# Altium schematic sheet SBPeripherals.SchDoc(SBPeripherals)
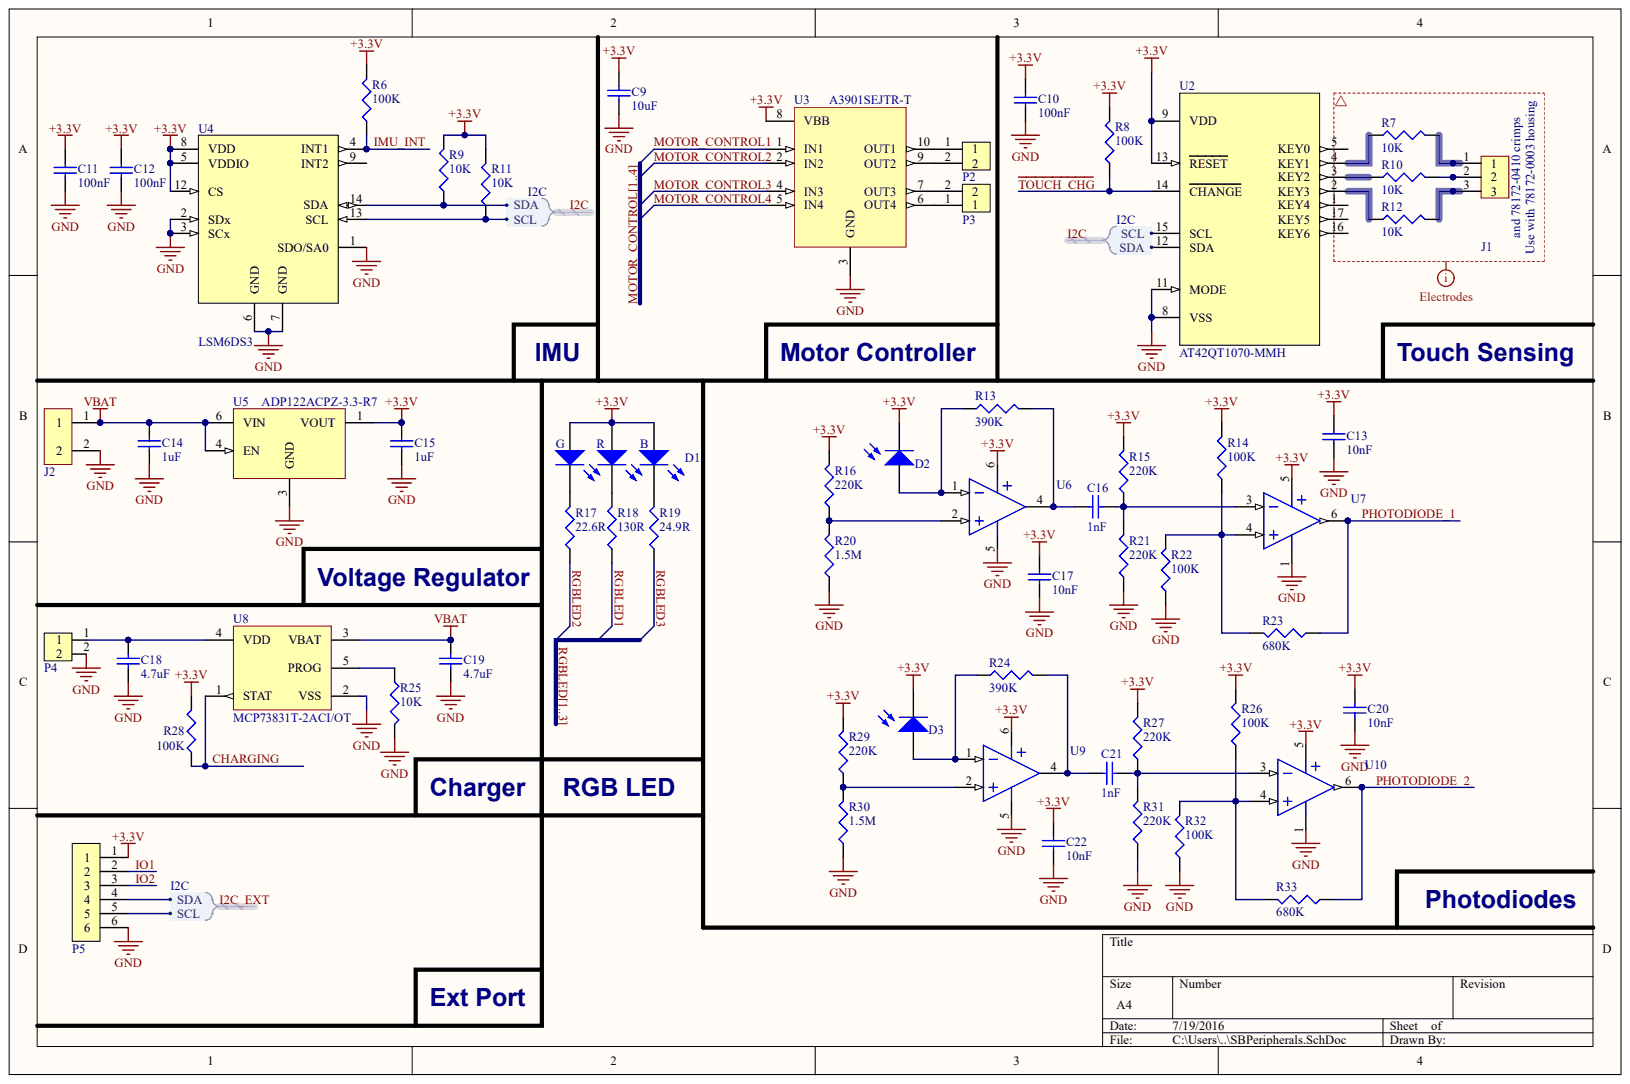
[diagram p0: SBPeripherals.SchDoc]

## Parts
C9  10uF  [0603]
C10  100nF  [0402]
C11  100nF  [0402]
C12  100nF  [0402]
C13  10nF  [0402]
C14  1uF  [0603]
C15  1uF  [0603]
C16  1nF  [0402]
C17  10nF  [0402]
C18  4.7uF  [0603]
C19  4.7uF  [0603]
C20  10nF  [0402]
C21  1nF  [0402]
C22  10nF  [0402]
D1  SMLVN6RGB1W1  [3.5x2.8 mm 6-SMD]  (value not drawn)
D2  PD15-22C/TR8  [PD15-22C/TR8]  (value not drawn)
D3  PD15-22C/TR8  [PD15-22C/TR8]  (value not drawn)
J1  78171-0003  [78171-0003]  (value not drawn)
J2  78171-0002  [78171-0002]  (value not drawn)
P2  HEADER 2X1 0.05" UNPOLARIZED  [HEADER 2X1 0.05" - UNPOLARIZED]  (value not drawn)
P3  HEADER 2X1 0.05" UNPOLARIZED  [HEADER 2X1 0.05" - UNPOLARIZED]  (value not drawn)
P4  CHARGING PAD  [Charging Pad]  (value not drawn)
P5  EXTENSION_PORT  [EXTENSION_PORT]  (value not drawn)
R6  100K  [0402]
R7  10K  [0402]
R8  100K  [0402]
R9  10K  [0402]
R10  10K  [0402]
R11  10K  [0402]
R12  10K  [0402]
R13  390K  [0402]
R14  100K  [0402]
R15  220K  [0402]
R16  220K  [0402]
R17  22.6R  [0402]
R18  130R  [0402]
R19  24.9R  [0402]
R20  1.5M  [0402]
R21  220K  [0402]
R22  100K  [0402]
R23  680K  [0402]
R24  390K  [0402]
R25  10K  [0402]
R26  100K  [0402]
R27  220K  [0402]
R28  100K  [0402]
R29  220K  [0402]
R30  1.5M  [0402]
R31  220K  [0402]
R32  100K  [0402]
R33  680K  [0402]
U2  AT42QT1070-MMH  [QFN45P300X300X85_HS-21L]
U3  A3901SEJTR-T  [DFN50P300X300X75_HS-11N]
U4  LSM6DS3  [LGA-14 2.5x3x0.86 mm 14L]
U5  ADP122ACPZ-3.3-R7  [LFCSP-6 2.0X2.0X0.55]
U6  TSV991AIQ1T  [DFN6-1.3x1.6x0.55]  (value not drawn)
U7  NCS2200AMUT1G  [UDFN6-1.2x1.0]  (value not drawn)
U8  MCP73831T-2ACI/OT  [SOT-23-OT5_L]
U9  TSV991AIQ1T  [DFN6-1.3x1.6x0.55]  (value not drawn)
U10  NCS2200AMUT1G  [UDFN6-1.2x1.0]  (value not drawn)

## Nets
+3.3V: C9.2 C10.2 C11.2 C12.2 C13.2 C15.2 C17.2 C20.2 C22.2 D1.1 D1.2 D1.3 D2.2 D3.2 P5.1 R6.2 R8.2 R9.2 R11.2 R14.2 R15.2 R16.2 R26.2 R27.2 R28.2 R29.2 U2.9(VDD) U2.13(RESET) U3.8(VBB) U4.5(VDDIO) U4.8(VDD) U4.12(CS) U5.1(VOUT) U6.6 U7.5 U9.6 U10.5
GND: C9.1 C10.1 C11.1 C12.1 C13.1 C14.1 C15.1 C17.1 C18.1 C19.1 C20.1 C22.1 J2.2 P4.2 P5.6 R20.1 R21.1 R22.1 R25.2 R30.1 R31.1 R32.1 U2.8(VSS) U2.11(MODE) U2.21 U3.3(GND) U3.11 U4.1(SDO/SA0) U4.2(SDx) U4.3(SCx) U4.6(GND) U4.7(GND) U5.2 U5.3(GND) U5.5 U5.7 U6.5 U7.1 U8.2(VSS) U9.5 U10.1
VBAT: C14.2 C19.2 J2.1 U5.4(EN) U5.6(VIN) U8.3(VBAT)
CHARGING: R28.1 U8.1(STAT)
I2C.SCL: R11.1 U2.15(SCL) U4.13(SCL)
I2C.SDA: R9.1 U2.12(SDA) U4.14(SDA)
I2C_EXT.SCL: P5.5
I2C_EXT.SDA: P5.4
IMU_INT: R6.1 U4.4(INT1)
IO1: P5.2
IO2: P5.3
MOTOR_CONTROL1: U3.1(IN1)  [also labelled MOTOR_CONTROL]
MOTOR_CONTROL2: U3.2(IN2)  [also labelled MOTOR_CONTROL]
MOTOR_CONTROL3: U3.4(IN3)  [also labelled MOTOR_CONTROL]
MOTOR_CONTROL4: U3.5(IN4)  [also labelled MOTOR_CONTROL]
PHOTODIODE_1: R23.1 U7.6
PHOTODIODE_2: R33.1 U10.6
RGBLED1: R18.1  [also labelled RGBLED]
RGBLED2: R17.1  [also labelled RGBLED]
RGBLED3: R19.1  [also labelled RGBLED]
~{TOUCH_CHG}: R8.1 U2.14(CHANGE)
NetC16_1: C16.1 R13.2 U6.4
NetC16_2: C16.2 R15.1 R21.2 U7.3
NetC18_2: C18.2 P4.1 U8.4(VDD)
NetC21_1: C21.1 R24.2 U9.4
NetC21_2: C21.2 R27.1 R31.2 U10.3
NetD1_4: D1.4 R17.2
NetD1_5: D1.5 R18.2
NetD1_6: D1.6 R19.2
NetD2_1: D2.1 R13.1 U6.1
NetD3_1: D3.1 R24.1 U9.1
NetJ1_1: J1.1 R7.2
NetJ1_2: J1.2 R10.2
NetJ1_3: J1.3 R12.2
NetP2_1: P2.1 U3.10(OUT1)
NetP2_2: P2.2 U3.9(OUT2)
NetP3_1: P3.1 U3.6(OUT4)
NetP3_2: P3.2 U3.7(OUT3)
NetR7_1: R7.1 U2.4(KEY1)
NetR10_1: R10.1 U2.3(KEY2)
NetR12_1: R12.1 U2.2(KEY3)
NetR14_1: R14.1 R22.2 R23.2 U7.4
NetR16_1: R16.1 R20.2 U6.2
NetR25_1: R25.1 U8.5(PROG)
NetR26_1: R26.1 R32.2 R33.2 U10.4
NetR29_1: R29.1 R30.2 U9.2
N00068:

Not connected: U2 1(KEY4) 5(KEY0) 16(KEY6) 17(KEY5); U4 9(INT2)

## Library data of the parts
C9 = 10uF: 10uF 0603 X5R 6.3V ±20%; Component Kind: Standard; Library Name: SBComponentsSchematics.SchLib; Supplier 1: Farnell; Supplier 2: Digi-Key; Supplier Part Number 1: 2456110; Supplier Part Number 2: 490-10475-1-ND; Value: 10uF
C10-C12 = 100nF: 100nF 0402 X7R 16V ±10%; Component Kind: Standard; Library Name: SBComponentsSchematics.SchLib; Supplier 1: Farnell; Supplier 2: Digi-Key; Supplier Part Number 1: 1288252; Supplier Part Number 2: 399-3521-1-ND; Value: 100nF
C13 C17 C20 C22 = 10nF: 10nF 0402 X7R 25V ±5%; Component Kind: Standard; Library Name: SBComponentsSchematics.SchLib; Supplier 1: Farnell; Supplier 2: Digi-Key; Supplier Part Number 1: 1692285; Supplier Part Number 2: 399-1279-1-ND; Value: 10nF
C14 C15 = 1uF: 1uF 0603 X5R 10V ±10%; Component Kind: Standard; Library Name: SBComponentsSchematics.SchLib; Supplier 1: Farnell; Supplier 2: Digi-Key; Supplier Part Number 1: 1759399; Supplier Part Number 2: 478-1251-1-ND; Value: 1uF
C16 C21 = 1nF: 1nF 0402 X7R 50V ±10%; Component Kind: Standard; Library Name: SBComponentsSchematics.SchLib; Supplier 1: Farnell; Supplier 2: Digi-Key; Supplier Part Number 1: 1414573; Supplier Part Number 2: 399-1032-1-ND; Value: 1nF
C18 C19 = 4.7uF: 4.7uF 0603 X5R 10V ±10%; Component Kind: Standard; Library Name: SBComponentsSchematics.SchLib; Supplier 1: Farnell; Supplier 2: Digi-Key; Supplier Part Number 1: 2362098; Supplier Part Number 2: 445-5170-1-ND; Value: 4.7uF
D1 = SMLVN6RGB1W1: ROHM SMLVN6RGB1W1 LED Tri-Color Blue/Green/Red Chip LED 6-Pin 1411 T/RLED Tri-Color Blue/Green/Red Chip LED 6-Pin 1411; Component Kind: Standard; Library Name: SBComponentsSchematics.SchLib; Supplier 1: Mouser; Supplier 2: Digi-Key; Supplier Part Number 1: 755-SMLVN6RGB1W1; Supplier Part Number 2: 846-1187-1-ND
D2 D3 = PD15-22C/TR8: Everlight PD15-22C/TR8 Silicon PIN Photodiode; Component Kind: Standard; Library Name: SBComponentsSchematics.SchLib; Supplier 1: Digi-Key; Supplier Part Number 1: 1080-1367-1-ND
J1 = 78171-0003: Molex Pico-EZmate™ Wire-to-Board Header, 3 contacts; Component Kind: Standard; Library Name: SBComponentsSchematics.SchLib; Supplier 1: Farnell; Supplier 2: Digi-Key; Supplier Part Number 1: 2313562; Supplier Part Number 2: WM5409CT-ND
J2 = 78171-0002: Molex Pico-EZmate™ Wire-to-Board Header, 2 contacts; Component Kind: Standard; Library Name: SBComponentsSchematics.SchLib; Supplier 1: Farnell; Supplier 2: Digi-Key; Supplier Part Number 1: 2313710; Supplier Part Number 2: WM4459CT-ND
R6 R8 R14 R22 R26 R28 R32 = 100K: 100K 0402 1/16W ±1%; Component Kind: Standard; Library Name: SBComponentsSchematics.SchLib; Supplier 1: Farnell; Supplier 2: Digi-Key; Supplier Part Number 1: 2072519; Supplier Part Number 2: 541-100KLCT-ND; Value: 100K
R7 R9-R12 R25 = 10K: 10K 0402 1/16W ±1%; Component Kind: Standard; Library Name: SBComponentsSchematics.SchLib; Supplier 1: Farnell; Supplier 2: Digi-Key; Supplier Part Number 1: 2072517; Supplier Part Number 2: 541-10.0KLCT-ND; Value: 10K
R13 R24 = 390K: 390K 0402 1/16W ±1%; Component Kind: Standard; Library Name: SBComponentsSchematics.SchLib; Supplier 1: Farnell; Supplier 2: Digi-Key; Supplier 3: Mouser; Supplier Part Number 1: 2302905; Supplier Part Number 2: P390KLCT-ND; Supplier Part Number 3: 667-ERJ-2RKF3903X; Value: 390K
R15 R16 R21 R27 R29 R31 = 220K: 220K 0402 1/16W ±1%; Component Kind: Standard; Library Name: SBComponentsSchematics.SchLib; Supplier 1: Farnell; Supplier 2: Digi-Key; Supplier 3: Mouser; Supplier Part Number 1: 9239510; Supplier Part Number 2: 311-220KLRCT-ND; Supplier Part Number 3: 603-RC0402FR-07220KL; Value: 220K
R17 = 22.6R: 22.6R 0402 1/16W ±0.05R; Component Kind: Standard; Library Name: SBComponentsSchematics.SchLib; Supplier 1: Farnell; Supplier 2: Digi-Key; Supplier Part Number 1: 2302454; Supplier Part Number 2: P22.6LCT-ND; Value: 22.6R
R18 = 130R: 130R 0402 1/16W ±0.05R; Component Kind: Standard; Library Name: SBComponentsSchematics.SchLib; Supplier 1: Farnell; Supplier 2: Digi-Key; Supplier Part Number 1: 2059115; Supplier Part Number 2: P130LCT-ND; Value: 130R
R19 = 24.9R: 24.9R 0402 1/16W ±0.05R; Component Kind: Standard; Library Name: SBComponentsSchematics.SchLib; Supplier 1: Farnell; Supplier 2: Digi-Key; Supplier Part Number 1: 2059102; Supplier Part Number 2: P24.9LCT-ND; Value: 24.9R
R20 R30 = 1.5M: 1.5M 0402 1/16W ±1%; Component Kind: Standard; Library Name: SBComponentsSchematics.SchLib; Supplier 1: Farnell; Supplier 2: Digi-Key; Supplier Part Number 1: 2141473; Supplier Part Number 2: 541-1.50MLCT-ND; Value: 1.5M
R23 R33 = 680K: 680K 0402 1/16W ±1%; Component Kind: Standard; Library Name: SBComponentsSchematics.SchLib; Supplier 1: Farnell; Supplier 2: Digi-Key; Supplier 3: Mouser; Supplier Part Number 1: 2302935; Supplier Part Number 2: P680KLCT-ND; Supplier Part Number 3: 667-ERJ-2RKF6803X; Value: 680K
U2 = AT42QT1070-MMH: Atmel Seven-channel QTouch® Touch Sensor IC; Component Kind: Standard; Library Name: SBComponentsSchematics.SchLib; Supplier 1: Digi-Key; Supplier Part Number 1: AT42QT1070-MMHCT-ND
U3 = A3901SEJTR-T: ALLEGRO MICROSYSTEMS - A3901SEJTR-T - IC, MOTOR DRIVER; Component Kind: Standard; Library Name: SBComponentsSchematics.SchLib; Supplier 1: Farnell; Supplier 2: Digi-Key; Supplier Part Number 1: 1651947; Supplier Part Number 2: 620-1159-1-ND
U4 = LSM6DS3: STMicroelectronics iNEMO inertial module:   3D accelerometer and 3D gyroscope; Component Kind: Standard; Library Name: SBComponentsSchematics.SchLib; Supplier 1: Farnell; Supplier 2: Digi-Key; Supplier Part Number 1: 2474253; Supplier Part Number 2: 497-15383-1-ND
U5 = ADP122ACPZ-3.3-R7: Analog Devices ADP122ACPZ-3.3-R7 - 5.5 V Input, 300 mA, Low Quiescent  Current, CMOS Linear Regulator; Component Kind: Standard; Library Name: SBComponentsSchematics.SchLib; Supplier 1: Farnell; Supplier 2: Digi-Key; Supplier Part Number 1: 2457164; Supplier Part Number 2: ADP122ACPZ-3.3-R7CT-ND
U6 U9 = TSV991AIQ1T: ST TSV991AIQ1T Rail-to-rail input/output 20 MHz GBP opamp; Component Kind: Standard; Library Name: SBComponentsSchematics.SchLib; Supplier 1: Mouser; Supplier 2: Digi-Key; Supplier Part Number 1: 511-TSV991AIQ1T; Supplier Part Number 2: 497-15999-1-ND
U7 U10 = NCS2200AMUT1G: Low Voltage Comparator; Component Kind: Standard; Library Name: SBComponentsSchematics.SchLib; Supplier 1: Farnell; Supplier 2: Mouser; Supplier 3: Digi-Key; Supplier Part Number 1: 1369752; Supplier Part Number 2: 863-NCS2200AMUT1G; Supplier Part Number 3: NCS2200AMUT1GOSCT-ND
U8 = MCP73831T-2ACI/OT: Miniature Single-Cell, Fully Integrated Li-Ion, Li-Polymer Charge Management Controller, 4.2V, 5-Pin SOT-23, Industrial Temperature, Tape and Reel; Code_IPC: SOT23-5; Component Kind: Standard; ComponentLink1Description: Datasheet; ComponentLink1URL: http://ww1.microchip.com/downloads/en/DeviceDoc/21984e.pdf; ComponentLink2Description: Packaging Specification; ComponentLink2URL: http://www.microchip.com/stellent/groups/techpub_sg/documents/packagingspec/en012702.pdf; ComponentLink3Description: Microchip.com Home; ComponentLink3URL: http://www.microchip.com/; DatasheetVersion: revE, Sep-2008; LatestRevisionDate: 27-Nov-2009; LatestRevisionNote: Updated to datasheet revision E, Sep-2008; Library Name: SBComponentsSchematics.SchLib; PackageDescription: 5-Lead Plastic Small Outline Transistor (OT) [SOT-23]; PackageReference: SOT-23-OT5; PackageVersion: revBB, Aug-2009; Published: 29-Jan-2009; Supplier 1: Farnell; Supplier Part Number 1: 1332158; Publisher: Altium Limited
P2 P3 = HEADER 2X1 0.05" UNPOLARIZED: Component Kind: Standard; Library Name: SBComponentsSchematics.SchLib
P4 = CHARGING PAD: Component Kind: Standard; Library Name: SBComponentsSchematics.SchLib
P5 = EXTENSION_PORT: Component Kind: Standard; Library Name: SBComponentsSchematics.SchLib
